# Altium schematic sheet 10_CONNECTOR.SchDoc(10_CONNECTOR)
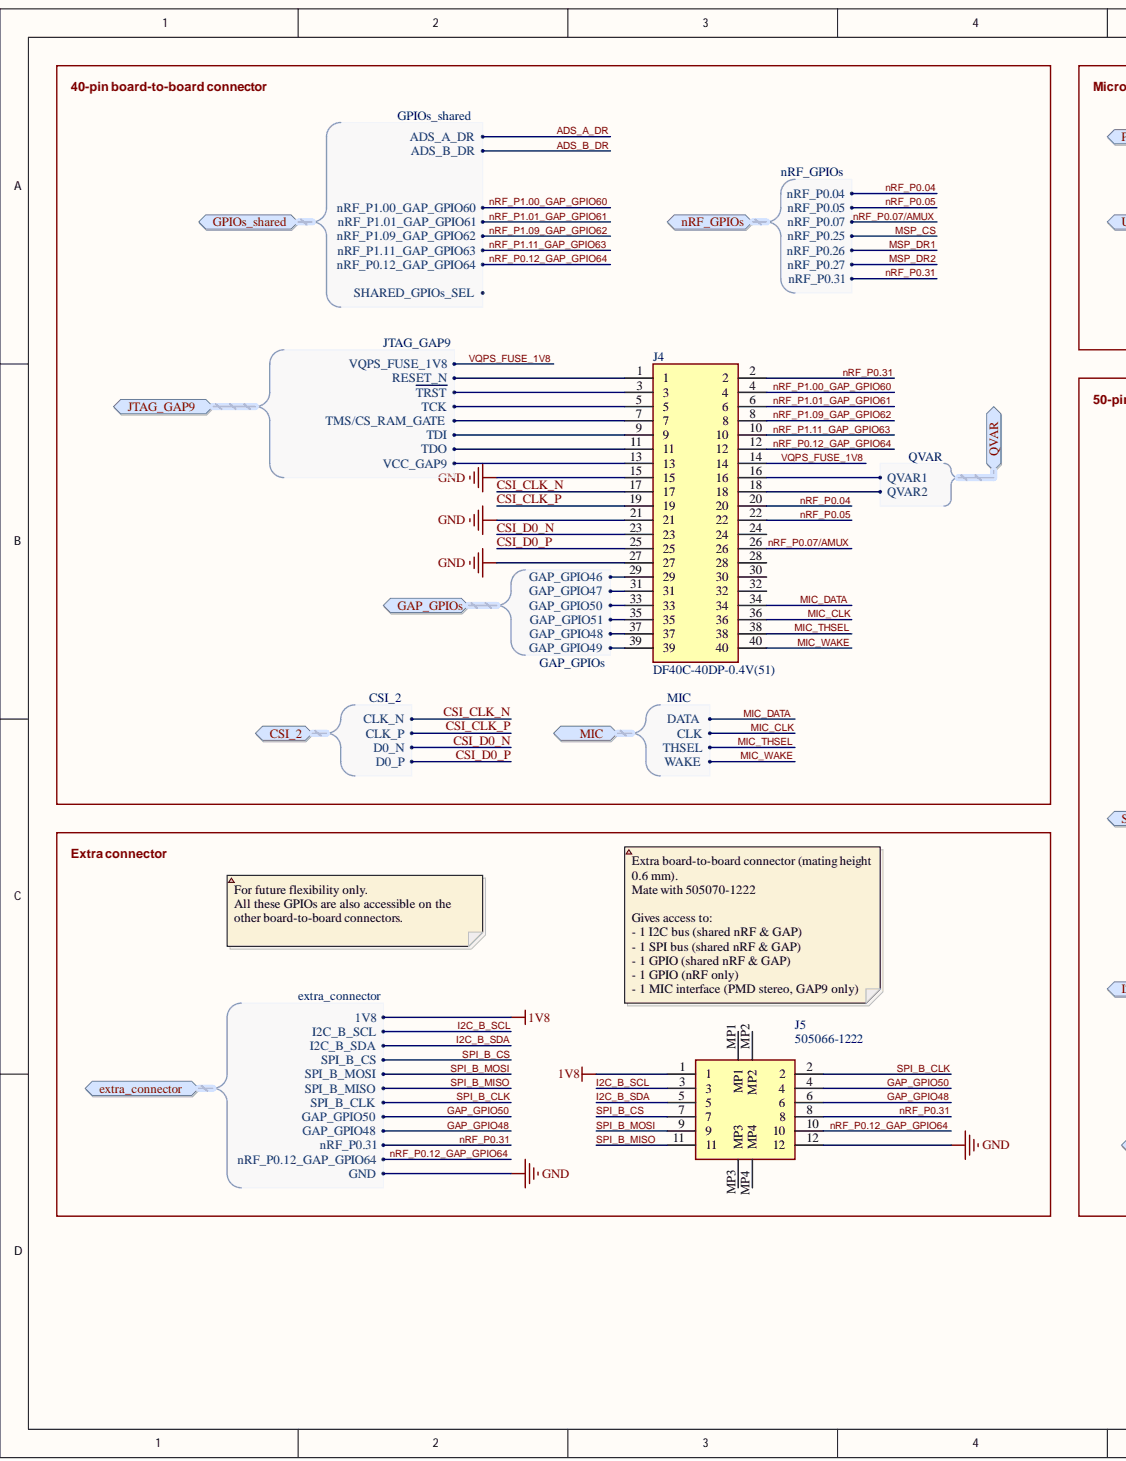
[diagram p0: 10_CONNECTOR.SchDoc, left]
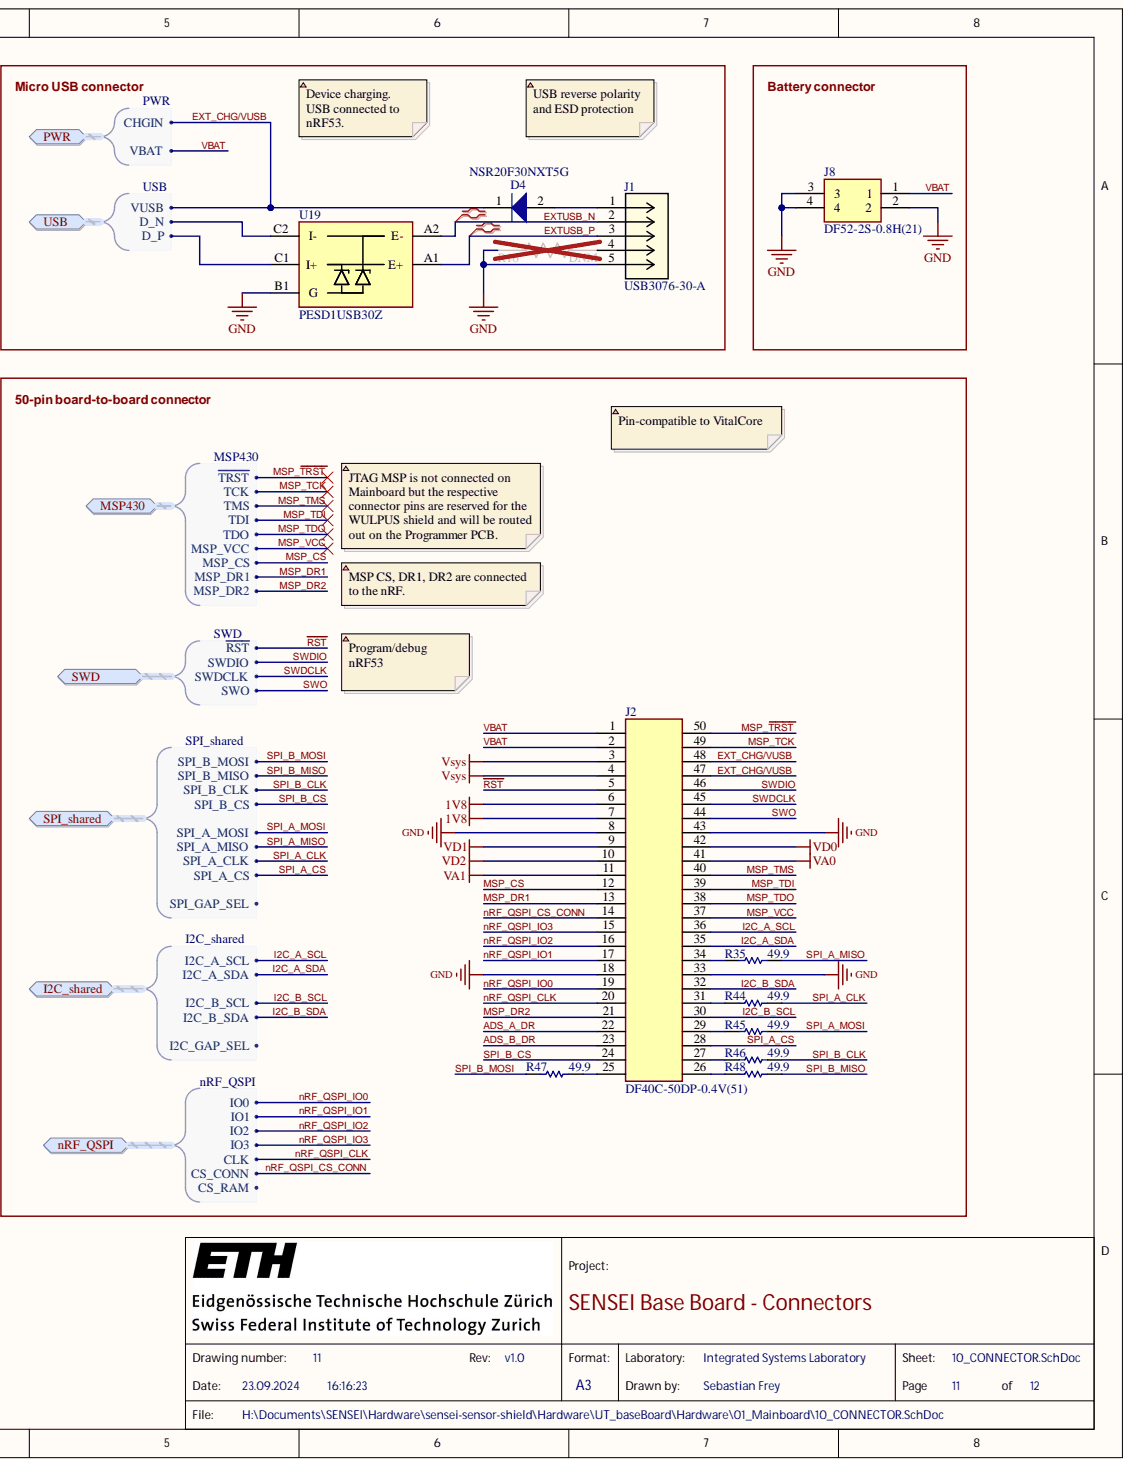
[diagram p1: 10_CONNECTOR.SchDoc, right]

## Parts
D4  NSR20F30NXT5G  [ONSC-DSN-2-512AB-01_V]
J1  USB3076-30-A  [CONN_USB3076-30-A_GCT]
J2  DF40C-50DP-0.4V(51)  [CONN_COMBI_50P]
J4  DF40C-40DP-0.4V(51)  [FP-DF40C-40DP-0_4V_51-MFG]
J5  505066-1222  [5050661222]
J8  DF52-2S-0.8H(21)  [DF522S08H21]
R16  DNM  [0201]
R35  Resistance: 49.9  [RESC0603X28X15ML05T05]  (hidden comment: CRCW020149R9FNED)
R44  Resistance: 49.9  [RESC0603X28X15ML05T05]  (hidden comment: CRCW020149R9FNED)
R45  Resistance: 49.9  [RESC0603X28X15ML05T05]  (hidden comment: CRCW020149R9FNED)
R46  Resistance: 49.9  [RESC0603X28X15ML05T05]  (hidden comment: CRCW020149R9FNED)
R47  Resistance: 49.9  [RESC0603X28X15ML05T05]  (hidden comment: CRCW020149R9FNED)
R48  Resistance: 49.9  [RESC0603X28X15ML05T05]  (hidden comment: CRCW020149R9FNED)
U19  PESD1USB30Z  [WLCSP_5_2-1-2_P0.4]

## Ports
CSI_2, CSI_2.CLK_N, CSI_2.CLK_P, CSI_2.D0_N, CSI_2.D0_P, extra_connector, extra_connector.1V8, extra_connector.GAP_GPIO48, extra_connector.GAP_GPIO50, extra_connector.GND, extra_connector.I2C_B_SCL, extra_connector.I2C_B_SDA, extra_connector.nRF_P0.12_GAP_GPIO64, extra_connector.nRF_P0.31, extra_connector.SPI_B_CLK, extra_connector.SPI_B_CS, extra_connector.SPI_B_MISO, extra_connector.SPI_B_MOSI, GAP_GPIOs, GAP_GPIOs.GAP_GPIO46, GAP_GPIOs.GAP_GPIO47, GAP_GPIOs.GAP_GPIO48, GAP_GPIOs.GAP_GPIO49, GAP_GPIOs.GAP_GPIO50, GAP_GPIOs.GAP_GPIO51, GPIOs_shared, GPIOs_shared.ADS_A_DR, GPIOs_shared.ADS_B_DR, GPIOs_shared.nRF_P0.12_GAP_GPIO64, GPIOs_shared.nRF_P1.00_GAP_GPIO60, GPIOs_shared.nRF_P1.01_GAP_GPIO61, GPIOs_shared.nRF_P1.09_GAP_GPIO62, GPIOs_shared.nRF_P1.11_GAP_GPIO63, GPIOs_shared.SHARED_GPIOs_SEL, I2C_shared, I2C_shared.I2C_A_SCL, I2C_shared.I2C_A_SDA, I2C_shared.I2C_B_SCL, I2C_shared.I2C_B_SDA, I2C_shared.I2C_GAP_SEL, JTAG_GAP9, JTAG_GAP9.RESET_N, JTAG_GAP9.TCK, JTAG_GAP9.TDI, JTAG_GAP9.TDO, JTAG_GAP9.TMS/CS_RAM_GATE, JTAG_GAP9.VCC_GAP9, JTAG_GAP9.VQPS_FUSE_1V8, JTAG_GAP9.~{TRST}, MIC, MIC.CLK, MIC.DATA, MIC.THSEL, MIC.WAKE, MSP430, MSP430.MSP_CS, MSP430.MSP_DR1, MSP430.MSP_DR2, MSP430.MSP_VCC, MSP430.TCK, MSP430.TDI, MSP430.TDO, MSP430.TMS, MSP430.~{TRST}, nRF_GPIOs, nRF_GPIOs.nRF_P0.04, nRF_GPIOs.nRF_P0.05, nRF_GPIOs.nRF_P0.07, nRF_GPIOs.nRF_P0.25, nRF_GPIOs.nRF_P0.26, nRF_GPIOs.nRF_P0.27, nRF_GPIOs.nRF_P0.31, nRF_QSPI, nRF_QSPI.CLK, nRF_QSPI.CS_CONN, nRF_QSPI.CS_RAM, nRF_QSPI.IO0, nRF_QSPI.IO1, nRF_QSPI.IO2, nRF_QSPI.IO3, PWR, PWR.CHGIN, PWR.VBAT, QVAR, QVAR.QVAR1, QVAR.QVAR2, SPI_shared, SPI_shared.SPI_A_CLK, SPI_shared.SPI_A_CS, SPI_shared.SPI_A_MISO, SPI_shared.SPI_A_MOSI, SPI_shared.SPI_B_CLK, SPI_shared.SPI_B_CS, SPI_shared.SPI_B_MISO, SPI_shared.SPI_B_MOSI, SPI_shared.SPI_GAP_SEL, SWD, SWD.SWDCLK, SWD.SWDIO, SWD.SWO, SWD.~{RST}, USB, USB.D_N, USB.D_P, USB.VUSB

## Nets
VA0: J2.41
VA1: J2.11
VD0: J2.42
VD1: J2.9
VD2: J2.10
Vsys: J2.3 J2.4
1V8: J2.6 J2.7 J5.1 ; port extra_connector
ADS_A_DR: J2.22 ; port GPIOs_shared
ADS_B_DR: J2.23 ; port GPIOs_shared
CSI_CLK_N: J4.17 ; port CSI_2
CSI_CLK_P: J4.19 ; port CSI_2
CSI_D0_N: J4.23 ; port CSI_2
CSI_D0_P: J4.25 ; port CSI_2
EXT_CHG/VUSB: D4.1 J2.47 J2.48 ; port PWR, port USB
EXTUSB_N: J1.2 U19.A2(E-)
EXTUSB_P: J1.3 U19.A1(E+)
GAP_GPIO48: J5.6 ; port extra_connector
GAP_GPIO50: J5.4 ; port extra_connector
GND: J1.5 J2.8 J2.18 J2.33 J2.43 J4.15 J4.21 J4.27 J5.12 J8.2 J8.3 J8.4 R16.2 U19.B1(G) ; port extra_connector
I2C_A_SCL: J2.36 ; port I2C_shared
I2C_A_SDA: J2.35 ; port I2C_shared
I2C_B_SCL: J2.30 J5.3 ; port I2C_shared, port extra_connector
I2C_B_SDA: J2.32 J5.5 ; port I2C_shared, port extra_connector
MIC_CLK: J4.36 ; port MIC
MIC_DATA: J4.34 ; port MIC
MIC_THSEL: J4.38 ; port MIC
MIC_WAKE: J4.40 ; port MIC
MSP_CS: J2.12 ; port MSP430, port nRF_GPIOs
MSP_DR1: J2.13 ; port MSP430, port nRF_GPIOs
MSP_DR2: J2.21 ; port MSP430, port nRF_GPIOs
MSP_~{TRST}: J2.50 ; port MSP430
MSP_TCK: J2.49 ; port MSP430
MSP_TDI: J2.39 ; port MSP430
MSP_TDO: J2.38 ; port MSP430
MSP_TMS: J2.40 ; port MSP430
MSP_VCC: J2.37 ; port MSP430
nRF_P0.04: J4.20 ; port nRF_GPIOs
nRF_P0.05: J4.22 ; port nRF_GPIOs
nRF_P0.07/AMUX: J4.26 ; port nRF_GPIOs
nRF_P0.12_GAP_GPIO64: J4.12 J5.10 ; port GPIOs_shared, port extra_connector
nRF_P0.31: J4.2 J5.8 ; port extra_connector, port nRF_GPIOs
nRF_P1.00_GAP_GPIO60: J4.4 ; port GPIOs_shared
nRF_P1.01_GAP_GPIO61: J4.6 ; port GPIOs_shared
nRF_P1.09_GAP_GPIO62: J4.8 ; port GPIOs_shared
nRF_P1.11_GAP_GPIO63: J4.10 ; port GPIOs_shared
nRF_QSPI_CLK: J2.20 ; port nRF_QSPI
nRF_QSPI_CS_CONN: J2.14 ; port nRF_QSPI
nRF_QSPI_IO0: J2.19 ; port nRF_QSPI
nRF_QSPI_IO1: J2.17 ; port nRF_QSPI
nRF_QSPI_IO2: J2.16 ; port nRF_QSPI
nRF_QSPI_IO3: J2.15 ; port nRF_QSPI
~{RST}: J2.5 ; port SWD
SPI_A_CLK: R44.2 ; port SPI_shared
SPI_A_CS: J2.28 ; port SPI_shared
SPI_A_MISO: R35.2 ; port SPI_shared
SPI_A_MOSI: R45.2 ; port SPI_shared
SPI_B_CLK: J5.2 R46.2 ; port SPI_shared, port extra_connector
SPI_B_CS: J2.24 J5.7 ; port SPI_shared, port extra_connector
SPI_B_MISO: J5.11 R48.2 ; port SPI_shared, port extra_connector
SPI_B_MOSI: J5.9 R47.1 ; port SPI_shared, port extra_connector
SWDCLK: J2.45 ; port SWD
SWDIO: J2.46 ; port SWD
SWO: J2.44 ; port SWD
VBAT: J2.1 J2.2 J8.1 ; port PWR
VQPS_FUSE_1V8: J4.14 ; port JTAG_GAP9
NetD4_2: D4.2 J1.1
NetJ1_4: J1.4 R16.1
NetJ2_25: J2.25 R47.2
NetJ2_26: J2.26 R48.1
NetJ2_27: J2.27 R46.1
NetJ2_29: J2.29 R45.1
NetJ2_31: J2.31 R44.1
NetJ2_34: J2.34 R35.1
NetJ4_1: J4.1 ; port JTAG_GAP9
NetJ4_3: J4.3 ; port JTAG_GAP9
NetJ4_5: J4.5 ; port JTAG_GAP9
NetJ4_7: J4.7 ; port JTAG_GAP9
NetJ4_9: J4.9 ; port JTAG_GAP9
NetJ4_11: J4.11 ; port JTAG_GAP9
NetJ4_13: J4.13 ; port JTAG_GAP9
NetJ4_16: J4.16 ; port QVAR
NetJ4_18: J4.18 ; port QVAR
NetJ4_29: J4.29 ; port GAP_GPIOs
NetJ4_31: J4.31 ; port GAP_GPIOs
NetJ4_33: J4.33 ; port GAP_GPIOs
NetJ4_35: J4.35 ; port GAP_GPIOs
NetJ4_37: J4.37 ; port GAP_GPIOs
NetJ4_39: J4.39 ; port GAP_GPIOs
NetU19_C1: U19.C1(I+) ; port USB
NetU19_C2: U19.C2(I-) ; port USB
N00006: ; port I2C_shared
N00029: ; port GPIOs_shared
N00034: ; port SPI_shared
N00047: ; port nRF_QSPI

Not connected: J4 24 28 30 32; J5 MP1 MP2 MP3 MP4

## Library data of the parts
D4 = CMP-1055-00233-1: Schottky Barrier Diode, 2-Pin DSN, Pb-Free, Tape and Reel; Manufacturer: On Semiconductor; Configuration: Single; IFSM Max (A): 28; Component Kind: Standard; ComponentLink1Description: Manufacturer URL; ComponentLink1URL: http://www.onsemi.com/; ComponentLink2Description: Datasheet; ComponentLink2URL: http://www.onsemi.com/pub_link/Collateral/NSR20F30-D.PDF; ComponentLink3Description: Package Specification; ComponentLink3URL: http://www.onsemi.cn/pub_link/Collateral/152AB.PDF; DatasheetVersion: Rev. 3; Library Name: Altium Content Vault; Mounting Technology: Surface Mount; PackageDescription: 2-Pin Micro Molded Package, Body 1.6 x 0.8 mm; PackageReference: DSN-2-512AB-01; PackageVersion: Rev. B, 01/2011; Packing: Tape and Reel; PartNumber: NSR20F30NXT5G; RoHS: TRUE
J1 = USB3076-30-A: No Description Available; Mfr_Name: Global Connector Technology; Component Kind: Standard; Library Name: EEG_SchLib.SchLib; Manufacturer_Part_Number: USB3076-30-A; RefDes: RefDes; Type: DEV; Copyright: Copyright (C) 2023 Ultra Librarian. All rights reserved.
J2 = CONN_50P_M_COMBI_INTERFACE: 50Pin 0.4mm pitch connector footprint that accepts (male) connectors intended for different stacking heights:  Hirose - DF40C-50DP-0.4V(51) for 1.5mm - 3mm stacking height  JAE - WP7B-P050VA1-R8000 - for 0.7mm stacking height; Component Kind: Standard; Library Name: EEG_SchLib.SchLib
J4 = DF40C-40DP-0.4V(51): CONN PLUG 40POS SMD GOLD; Current Rating: 300mA; Gender: Male; Mated Stacking Heights: 4mm; Number of Contacts: 40; Pitch: 0.4mm; Voltage Rating: 30V; Component Kind: Standard; Connector Type: Plug; Contact Material: Bronze; Contact Plating: Gold; Housing Material: Polymer; Lead Free: Lead Free; Library Name: EEG_SchLib.SchLib; Max Operating Temperature: 85°C; Min Operating Temperature: -35°C; Mount: Surface Mount; Number of Positions: 40; Number of Rows: 2; Orientation: Straight; Package Quantity: 1; Packaging: Tape and Reel; REACH SVHC: No SVHC; Termination: SMD/SMT
J5 = 505066-1222: Connector; Manufacturer_Name: Molex; Component Kind: Standard; Datasheet Link: https://www.molex.com/pdm_docs/sd/5050661222_sd.pdf; Height: 0.63mm; Library Name: SamacSys.SchLib; Manufacturer_Part_Number: 505066-1222; Mouser Part Number: 538-505066-1222; Mouser Price/Stock: https://www.mouser.co.uk/ProductDetail/Molex/505066-1222?qs=byeeYqUIh0OlQo7UKX%2FsWw%3D%3D
J8 = DF52-2S-0.8H_21_: Connector; Manufacturer_Name: Hirose; Component Kind: Standard; Datasheet Link: https://datasheet.datasheetarchive.com/originals/distributors/DKDS-30/591726.pdf; Height: 1mm; Library Name: SamacSys.SchLib; Manufacturer_Part_Number: DF52-2S-0.8H(21); Mouser Part Number: 798-DF52-2S-0.8H21; Mouser Price/Stock: https://www.mouser.co.uk/ProductDetail/Hirose-Connector/DF52-2S-0.8H21?qs=%2FKR40Cd6GUpAHUMGMtWbig%3D%3D
R16 = RES DNM: Resistor; Component Kind: Standard; Library Name: EEG_SchLib.SchLib; Value: DNM
R35 R44-R48 = CMP-2004-00655-1: Manufacturer: Vishay Dale; Component Kind: Standard; Library Name: Altium Content Vault; Part Number: CRCW020149R9FNED
U19 = PESD1USB30: ESD Suppressor Diode TVS Uni-Dir 5V 5-Pin WLCSP T/R; Manufacturer: NXP Semiconductors; Component Kind: Standard; Library Name: EEG_SchLib.SchLib; Manufacturer Part Number: PESD1USB30; Supplier 1: Mouser; Supplier Part Number 1: 771-PESD1USB30Z
